# Altium schematic sheet PLCBOARD.SchDoc
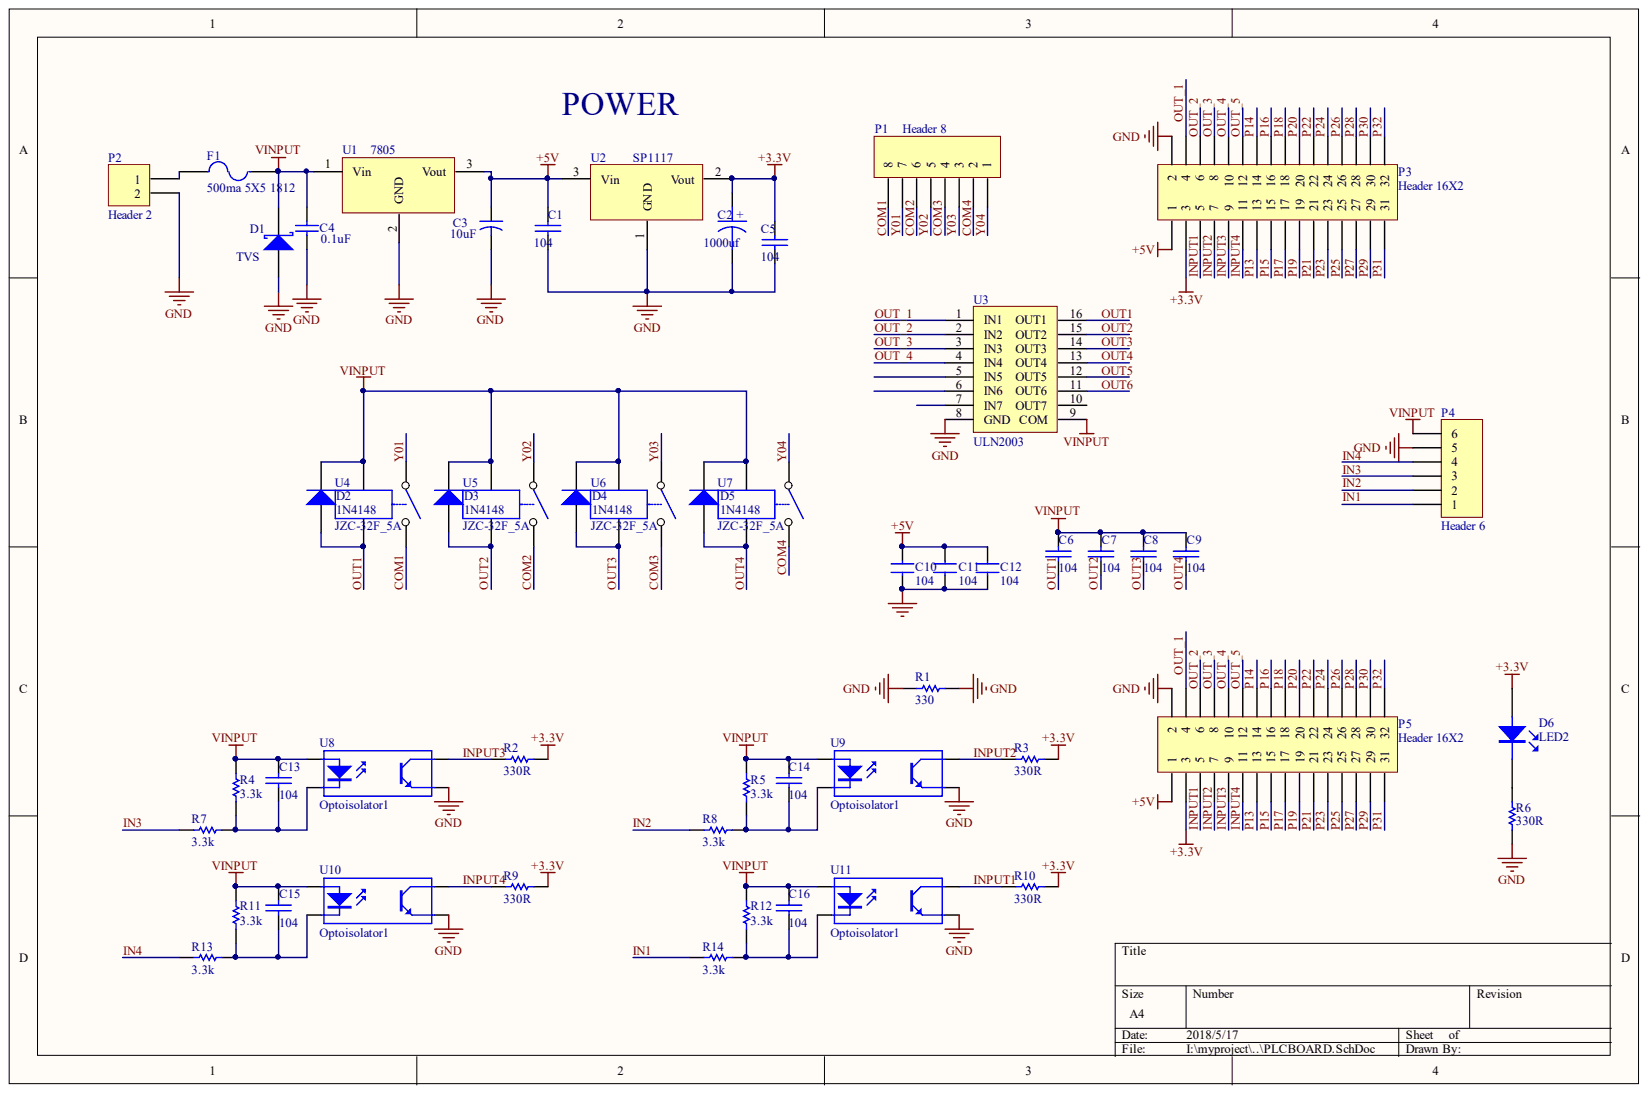
[diagram p0: PLCBOARD.SchDoc]

## Parts
C1  104
C2  1000uf
C3  value 10uF  (hidden comment: Cap2)
C4  value 0.1uF  (hidden comment: Cap)
C5  104
C6  104
C7  104
C8  104
C9  104
C10  104
C11  104
C12  104
C13  104
C14  104
C15  104
C16  104
D1  TVS
D2  1N4148
D3  1N4148
D4  1N4148
D5  1N4148
D6  LED2
F1  500ma 5X5 1812
P1  Header 8
P2  Header 2
P3  Header 16X2
P4  Header 6
P5  Header 16X2
R1  value 330  (hidden comment: Res1)
R2  value 330R  (hidden comment: Res1)
R3  value 330R  (hidden comment: Res1)
R4  value 3.3k  (hidden comment: Res1)
R5  value 3.3k  (hidden comment: Res1)
R6  value 330R  (hidden comment: Res1)
R7  value 3.3k  (hidden comment: Res1)
R8  value 3.3k  (hidden comment: Res1)
R9  value 330R  (hidden comment: Res1)
R10  value 330R  (hidden comment: Res1)
R11  value 3.3k  (hidden comment: Res1)
R12  value 3.3k  (hidden comment: Res1)
R13  value 3.3k  (hidden comment: Res1)
R14  value 3.3k  (hidden comment: Res1)
U1  7805
U2  SP1117
U3  ULN2003
U4  JZC-32F_5A
U5  JZC-32F_5A
U6  JZC-32F_5A
U7  JZC-32F_5A
U8  Optoisolator1
U9  Optoisolator1
U10  Optoisolator1
U11  Optoisolator1

## Nets
+3.3V: C2.1 C5.1 D6.1 P3.3 P5.3 R2.1 R3.1 R9.1 R10.1 U2.2
+5V: C1.1 C3.1 C10.2 C11.2 C12.2 P3.1 P5.1 U1.3 U2.3
GND: C1.2 C2.2 C3.2 C4.1 C5.2 C10.1 C11.1 C12.1 D1.1 P2.2 P3.2 P4.5 P5.2 R1.1 R1.2 R6.2 U1.2 U2.1 U3.8(GND) U8.3 U9.3 U10.3 U11.3
VINPUT: C4.2 C6.1 C7.1 C8.1 C9.1 C13.1 C14.1 C15.1 C16.1 D1.2 D2.2 D3.2 D4.2 D5.2 F1.2 P4.6 R4.1 R5.1 R11.1 R12.1 U1.1 U3.9(COM) U4.3 U5.3 U6.3 U7.3 U8.1 U9.1 U10.1 U11.1
COM1: P1.8 U4.2
COM2: P1.6 U5.2
COM3: P1.4 U6.2
COM4: P1.2 U7.2
IN1: P4.1 R14.1
IN2: P4.2 R8.1
IN3: P4.3 R7.1
IN4: P4.4 R13.1
INPUT1: P3.5 P5.5 R10.2 U11.4
INPUT2: P3.7 P5.7 R3.2 U9.4
INPUT3: P3.9 P5.9 R2.2 U8.4
INPUT4: P3.11 P5.11 R9.2 U10.4
OUT1: C6.2 D2.1 U3.16(OUT1) U4.4
OUT2: C7.2 D3.1 U3.15(OUT2) U5.4
OUT3: C8.2 D4.1 U3.14(OUT3) U6.4
OUT4: C9.2 D5.1 U3.13(OUT4) U7.4
OUT5: U3.12(OUT5)
OUT6: U3.11(OUT6)
OUT_1: P3.4 P5.4 U3.1(IN1)
OUT_2: P3.6 P5.6 U3.2(IN2)
OUT_3: P3.8 P5.8 U3.3(IN3)
OUT_4: P3.10 P5.10 U3.4(IN4)
OUT_5: P3.12 P5.12
P13: P3.13 P5.13
P14: P3.14 P5.14
P15: P3.15 P5.15
P16: P3.16 P5.16
P17: P3.17 P5.17
P18: P3.18 P5.18
P19: P3.19 P5.19
P20: P3.20 P5.20
P21: P3.21 P5.21
P22: P3.22 P5.22
P23: P3.23 P5.23
P24: P3.24 P5.24
P25: P3.25 P5.25
P26: P3.26 P5.26
P27: P3.27 P5.27
P28: P3.28 P5.28
P29: P3.29 P5.29
P30: P3.30 P5.30
P31: P3.31 P5.31
P32: P3.32 P5.32
Y01: P1.7 U4.1
Y02: P1.5 U5.1
Y03: P1.3 U6.1
Y04: P1.1 U7.1
NetC13_2: C13.2 R4.2 R7.2 U8.2
NetC14_2: C14.2 R5.2 R8.2 U9.2
NetC15_2: C15.2 R11.2 R13.2 U10.2
NetC16_2: C16.2 R12.2 R14.2 U11.2
NetD6_2: D6.2 R6.1
NetF1_1: F1.1 P2.1

Not connected: U3 5(IN5) 6(IN6) 7(IN7) 10(OUT7)

## Library data of the parts
C1-C16 D1-D6 F1 P1-P5 R1-R14 U1-U11 = (no symbol name)
  C3: Published: 23-Sep-2002; PackageReference: CAPR5-4X5; PackageDescription: Capacitor; 2 Leads
  C4: Published: 8-Jun-2000; LatestRevisionDate: 17-Jul-2002; LatestRevisionNote: Re-released for DXP Platform.; PackageReference: RAD-0.3; PackageDescription: Radial Cap, Thru-Hole; 2 Leads; 0.3 in Pin Spacing
  C10-C12: Value: 104
  D1: Published: 8-Jun-2000; LatestRevisionDate: 17-Jul-2002; LatestRevisionNote: Re-released for DXP Platform.; PackageReference: SMB; PackageDescription: DO-214-AA/SMB; 2 C-Bend Leads; Body 5.3 x 3.6 mm, inc. leads (LxW); Code_JEDEC: DO-214-AA
  D2-D5: Published: 8-Jun-2000; LatestRevisionDate: 17-Jul-2002; LatestRevisionNote: Re-released for DXP Platform.; PackageVersion: Sep-1996; PackageReference: SMC; PackageDescription: DO-214-AB/SMC; 2 C-Bend Leads; Body 7.9 x 5.9 mm, inc. leads (LxW); Code_JEDEC: DO-214-AB
  F1: Published: 8-Jun-2000; LatestRevisionDate: 17-Jul-2002; LatestRevisionNote: Re-released for DXP Platform.; PackageReference: PIN-W2/E2.8; PackageDescription: Thru-Hole; 2 Leads
  P1-P5: LatestRevisionDate: 17-Jul-2002; LatestRevisionNote: Re-released for DXP Platform.
  R1-R14: Published: 8-Jun-2000; LatestRevisionDate: 17-Jul-2002; LatestRevisionNote: Re-released for DXP Platform.; PackageReference: AXIAL-0.3; PackageDescription: Axial Device, Thru-Hole; 2 Leads; 0.3 in Pin Spacing
  U8-U11: Published: 24-Mar-1999; LatestRevisionDate: 04-Mar-2005; LatestRevisionNote: Sch pins 3 & 4 Swapped.; DatasheetVersion: Nov-2003; PackageReference: DIP-4
  C3 C4 D1-D5 F1 P1-P5 R1-R14 U8-U11: Publisher: Altium Limited
